# Altium schematic sheet Power.SchDoc(Power)
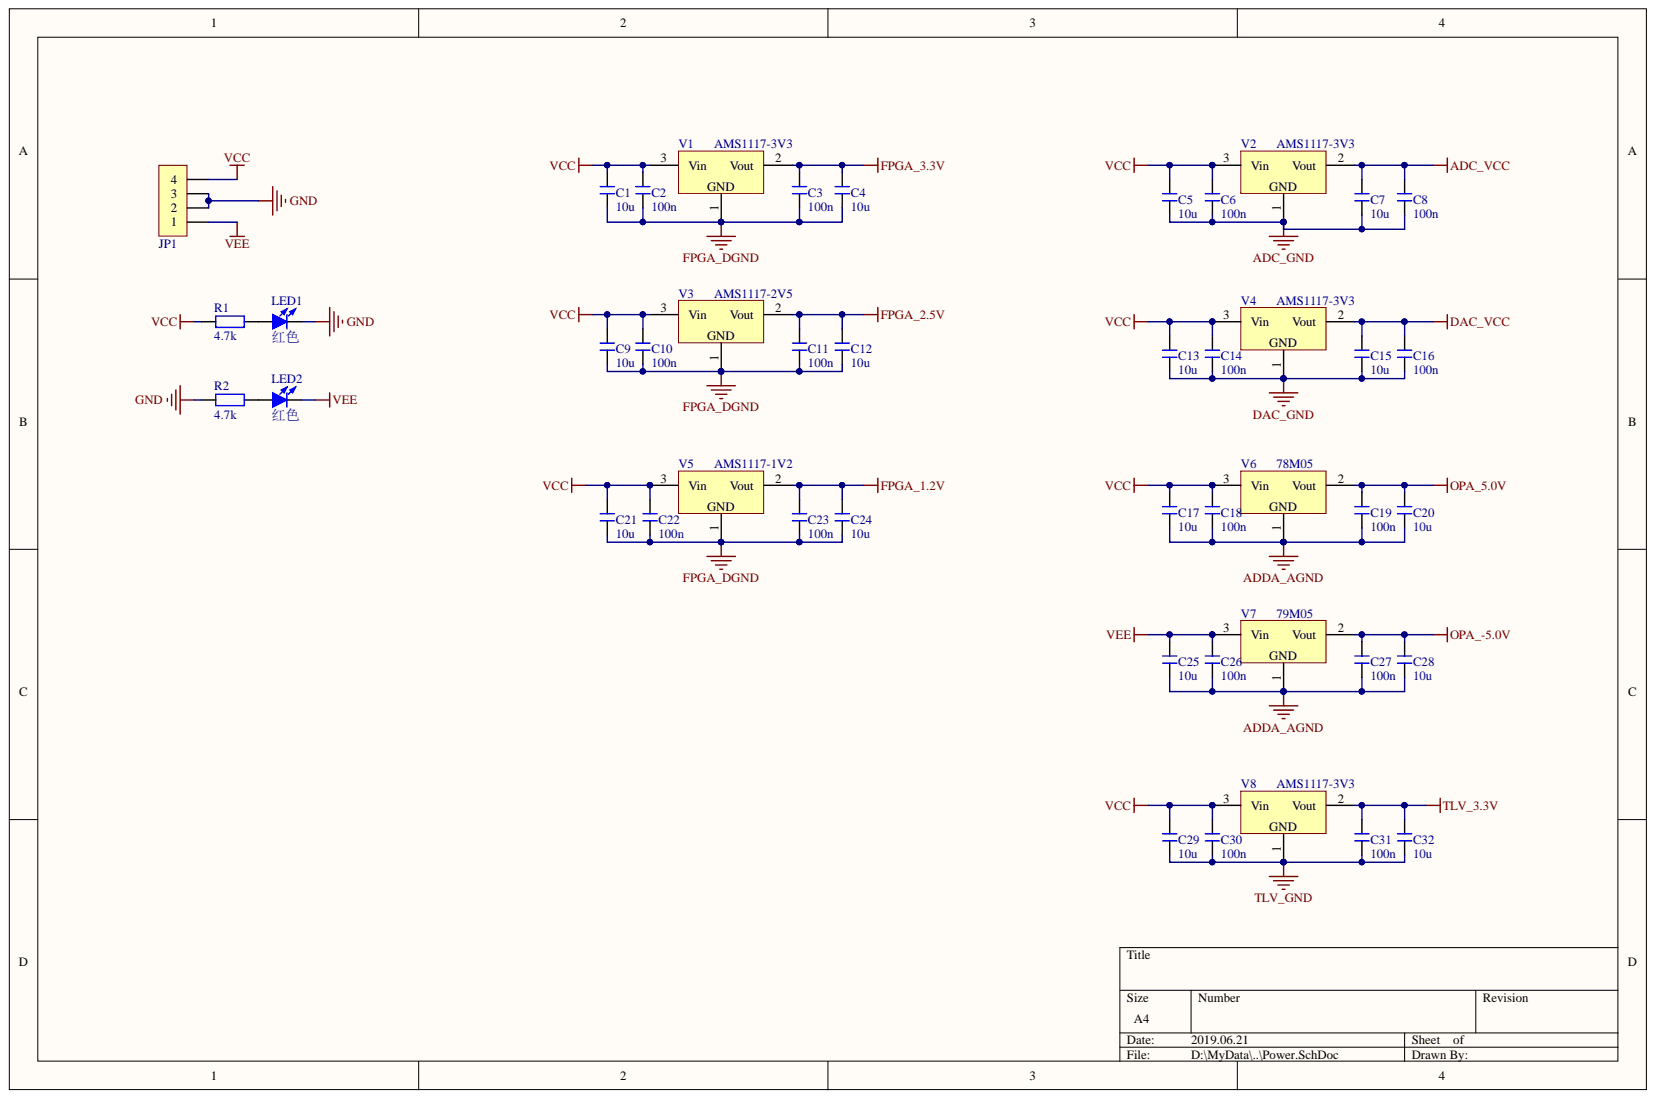
[diagram p0: Power.SchDoc]

## Parts
C1  10u  [C 0805_L]
C2  100n  [C 0805_L]
C3  100n  [C 0805_L]
C4  10u  [C 0805_L]
C5  10u  [C 0805_L]
C6  100n  [C 0805_L]
C7  10u  [C 0805_L]
C8  100n  [C 0805_L]
C9  10u  [C 0805_L]
C10  100n  [C 0805_L]
C11  100n  [C 0805_L]
C12  10u  [C 0805_L]
C13  10u  [C 0805_L]
C14  100n  [C 0805_L]
C15  10u  [C 0805_L]
C16  100n  [C 0805_L]
C17  10u  [C 0805_L]
C18  100n  [C 0805_L]
C19  100n  [C 0805_L]
C20  10u  [C 0805_L]
C21  10u  [C 0805_L]
C22  100n  [C 0805_L]
C23  100n  [C 0805_L]
C24  10u  [C 0805_L]
C25  10u  [C 0805_L]
C26  100n  [C 0805_L]
C27  100n  [C 0805_L]
C28  10u  [C 0805_L]
C29  10u  [C 0805_L]
C30  100n  [C 0805_L]
C31  100n  [C 0805_L]
C32  10u  [C 0805_L]
JP1  HDR-1X4  [KF2510-LI-4P]  (value not drawn)
LED1  红色  [LED 0805G]
LED2  红色  [LED 0805G]
R1  4.7k  [R 0805_L]
R2  4.7k  [R 0805_L]
V1  AMS1117-3V3  [SOT223_L]
V2  AMS1117-3V3  [SOT223_L]
V3  AMS1117-2V5  [SOT223_L]
V4  AMS1117-3V3  [SOT223_L]
V5  AMS1117-1V2  [SOT223_L]
V6  78M05  [SOT223_L]
V7  79M05  [SOT223_L]
V8  AMS1117-3V3  [SOT223_L]

## Nets
ADC_GND: C5.1 C6.1 C7.1 C8.1 V2.1
ADC_VCC: C7.2 C8.2 V2.2
ADDA_AGND: C17.1 C18.1 C19.1 C20.1 C25.1 C26.1 C27.1 C28.1 V6.1 V7.1
DAC_GND: C13.1 C14.1 C15.1 C16.1 V4.1
DAC_VCC: C15.2 C16.2 V4.2
FPGA_1.2V: C23.2 C24.2 V5.2
FPGA_2.5V: C11.2 C12.2 V3.2
FPGA_3.3V: C3.2 C4.2 V1.2
FPGA_DGND: C1.1 C2.1 C3.1 C4.1 C9.1 C10.1 C11.1 C12.1 C21.1 C22.1 C23.1 C24.1 V1.1 V3.1 V5.1
GND: JP1.2 JP1.3 LED1.2 R2.1
OPA_5.0V: C19.2 C20.2 V6.2
OPA_-5.0V: C27.2 C28.2 V7.2
TLV_3.3V: C31.2 C32.2 V8.2
TLV_GND: C29.1 C30.1 C31.1 C32.1 V8.1
VCC: C1.2 C2.2 C5.2 C6.2 C9.2 C10.2 C13.2 C14.2 C17.2 C18.2 C21.2 C22.2 C29.2 C30.2 JP1.4 R1.1 V1.3 V2.3 V3.3 V4.3 V5.3 V6.3 V8.3
VEE: C25.2 C26.2 JP1.1 LED2.2 V7.3
NetLED1_1: LED1.1 R1.2
NetLED2_1: LED2.1 R2.2

## Library data of the parts
C1-C32 = C: 无极性贴片电容; 版权归属: 源创客; BOM名称: 无极性贴片电容; Component Kind: Standard; Library Name: 3.1   - 电阻-电容-电感.SchLib; 学习资源: www.ooocke.com
JP1 = Header 4: 4P接插件; 版权归属: 源创客; BOM名称: 4P接插件; Component Kind: Standard; Library Name: 4.5   - 接插件-脚距2.54.SchLib; 学习资源: www.ooocke.com
LED1 LED2 = LED-SMD: 贴片LED; 版权归属: 源创客; BOM名称: 贴片LED; Component Kind: Standard; Library Name: 9.1   - LED.SchLib; 学习资源: www.ooocke.com
R1 R2 = R: 贴片电阻; 版权归属: 源创客; BOM名称: 贴片电阻; Component Kind: Standard; Library Name: 3.1   - 电阻-电容-电感.SchLib; 学习资源: www.ooocke.com
V1-V8 = AMS1117: 三端稳压芯片; BOM名称: 稳压芯片; 版权归属: 源创客; Component Kind: Standard; Library Name: 1.3   - 电源类芯片.SchLib; 学习资源: www.ooocke.com
